# Altium schematic sheet Comparator.SchDoc
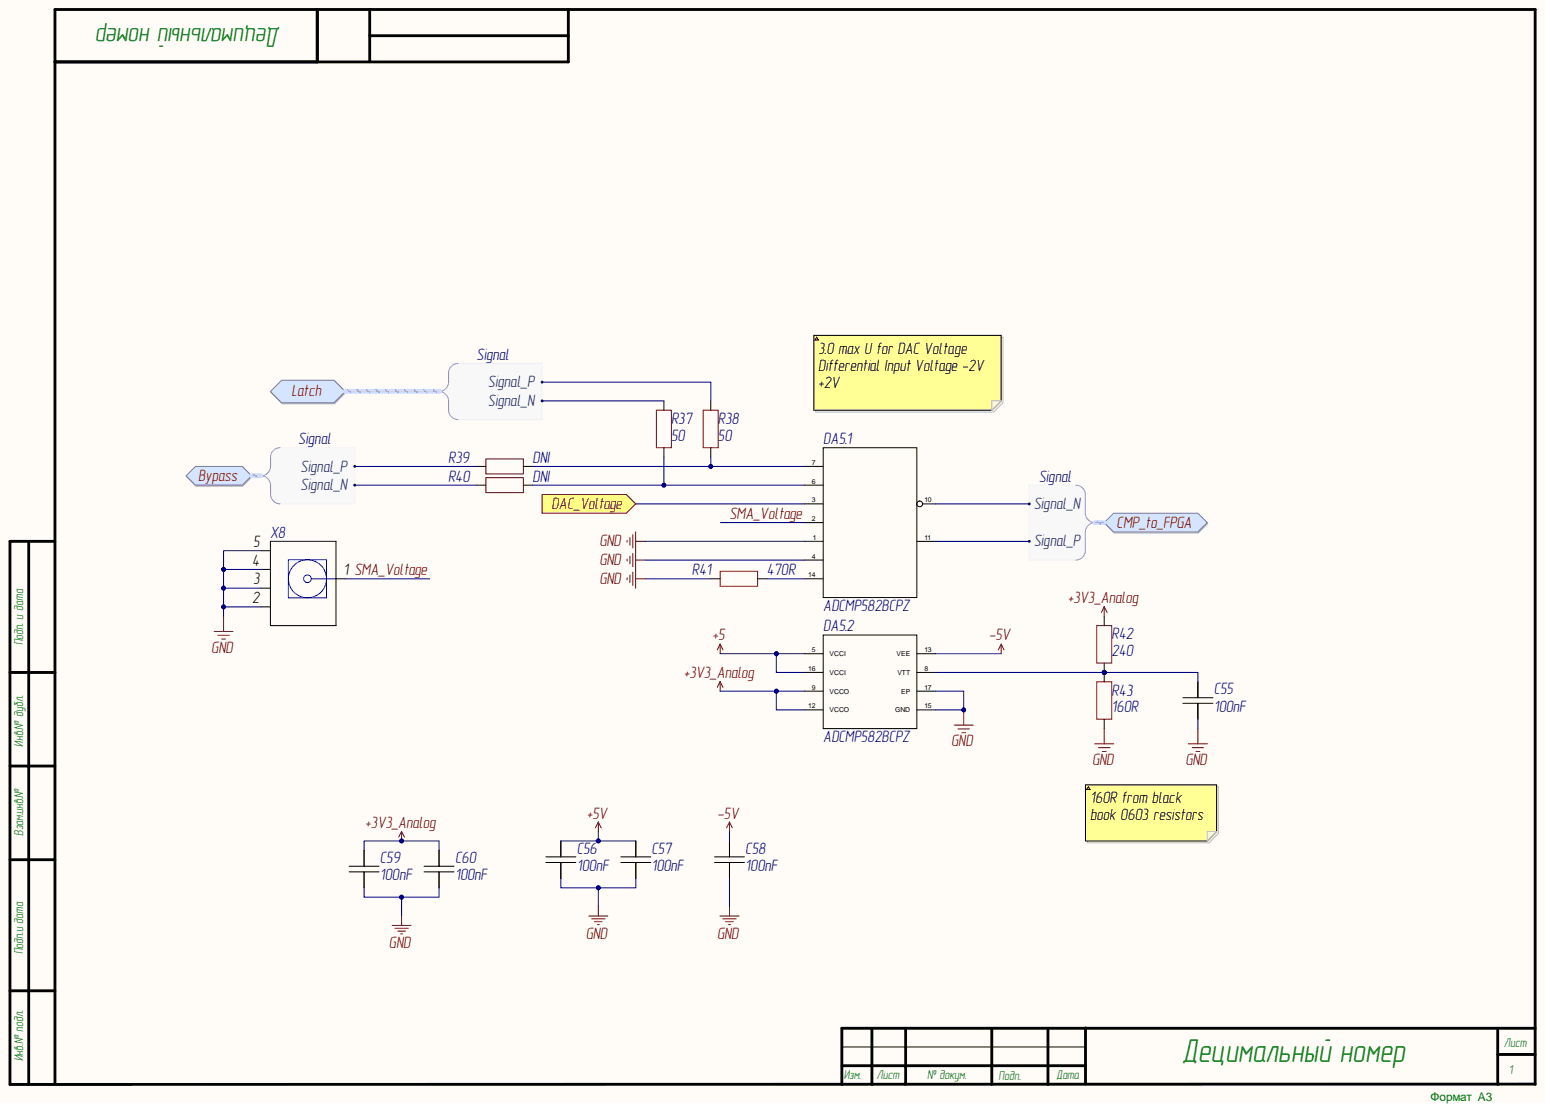
[diagram p0: Comparator.SchDoc]

## Parts
C55  value 100nF  [CAP0402]  (hidden comment: 100nF 50V)
C56  value 100nF  [CAP0402]  (hidden comment: 100nF 50V)
C57  value 100nF  [CAP0402]  (hidden comment: 100nF 50V)
C58  value 100nF  [CAP0402]  (hidden comment: 100nF 50V)
C59  value 100nF  [CAP0402]  (hidden comment: 100nF 50V)
C60  value 100nF  [CAP0402]  (hidden comment: 100nF 50V)
DA5  =Value  [ADI-CP-16-3_N]  (parts .1,.2)
R37  50  [RESC0402(1005)_M]
R38  50  [RESC0402(1005)_M]
R39  DNI  [RESC0402(1005)_M]
R40  DNI  [RESC0402(1005)_M]
R41  470R  [RESC0805L]
R42  240  [RESC0603N]
R43  160R  [RESC0603N]
X8  =Value  [60311042114502]

## Ports
Bypass, Bypass.Signal_N, Bypass.Signal_P, CMP_to_FPGA, CMP_to_FPGA.Signal_N, CMP_to_FPGA.Signal_P, DAC_Voltage, Latch, Latch.Signal_N, Latch.Signal_P

## Nets
+3V3_Analog: C59.1 C60.1 DA5.9(VCCO) DA5.12(VCCO) R42.2
+5: DA5.5(VCCI) DA5.16(VCCI)
+5V: C56.1 C57.1
-5V: C58.1 DA5.13(VEE)
GND: C55.1 C56.2 C57.2 C58.2 C59.2 C60.2 DA5.1 DA5.4 DA5.15(GND) DA5.17(EP) R41.1 R43.1 X8.2 X8.3 X8.4 X8.5
DAC_Voltage: DA5.3 ; port DAC_Voltage
SMA_Voltage: DA5.2 X8.1
NetC55_2: C55.2 DA5.8(VTT) R42.1 R43.2
NetDA5_6: DA5.6 R37.1 R40.1
NetDA5_7: DA5.7 R38.1 R39.1
NetDA5_10: DA5.10 ; port CMP_to_FPGA
NetDA5_11: DA5.11 ; port CMP_to_FPGA
NetDA5_14: DA5.14 R41.2
NetR37_2: R37.2 ; port Latch
NetR38_2: R38.2 ; port Latch
NetR39_2: R39.2 ; port Bypass
NetR40_2: R40.2 ; port Bypass

## Library data of the parts
C55-C60 = CAP0402: Manufacturer: Samsung Electro-Mechanics; ManufacturerAlt: JLCPCB; MPN: CL05B104KB54PNC; MPNAlt: C307331; BOMDescription: SMD CHIP CERAMIC CAPACITOR CAP0402 100nF 10% 50V  CL05B104KB54PNC; Database Table Name: Symbol_SchLib; MntType: SMD; Notes: Basic Part; Tolerance: 10%; CFootprint: CAP0402; ClassName: Capacitor; Component Kind: Standard; ComponentLink1Description: Datasheet; ComponentLink1URL: https://datasheet.lcsc.com/lcsc/1810191222_Samsung-Electro-Mechanics-CL05B104KB54PNC_C307331.pdf; Height: 0.5mm; Library Name: Library.DbLib; MSL: MSL 1; Package: CAP0402; Part Number: CAP0402; PartType: CHIP CERAMIC CAPACITOR; Pins: 2; RoHS: Comply; Voltage: 50V; Weight: 1.500mg
DA5 = ADCMP582BCPZ: Ultrafast SiGe Voltage Comparator, + / - 5 V, 180 ps Delay, Industrial, 16-pin QFN (CP-16-3), Reel; Manufacturer: AD; BOMDescription: SMD Comparator LFCSP-16 =Value    ADCMP582BCPZ; Database Table Name: Symbol_SchLib; MntType: SMD; CFootprint: ADI-CP-16-3_N; ClassName: Comparator; Component Kind: Standard; ComponentLink1Description: Datasheet; ComponentLink1URL: https://www.analog.com/media/en/technical-documentation/data-sheets/ADCMP580_581_582.pdf; Library Name: Library.DbLib; MPN: ADCMP582BCPZ; MSL: MSL 1; Package: LFCSP-16; Part Number: ADCMP582BCPZ; PartType: Comparator; Pins: 16; RoHS: Comply
R37 R38 = RES0402: BOMDescription: SMD CHIP RESISTOR RESC0402(1005)_M 50; Database Table Name: Symbol_SchLib; MntType: SMD; CFootprint: RESC0402(1005)_M; ClassName: Resistor; Component Kind: Standard; ComponentLink1Description: Datasheet; Library Name: Library.DbLib; MSL: MSL 1; Package: RESC0402(1005)_M; Part Number: RES0402; PartType: CHIP RESISTOR; Pins: 2; RoHS: Comply; Value: 50
R39 R40 = RES0402: BOMDescription: SMD CHIP RESISTOR RESC0402(1005)_M DNI; Database Table Name: Symbol_SchLib; MntType: SMD; CFootprint: RESC0402(1005)_M; ClassName: Resistor; Component Kind: Standard; ComponentLink1Description: Datasheet; Library Name: Library.DbLib; MSL: MSL 1; Package: RESC0402(1005)_M; Part Number: RES0402; PartType: CHIP RESISTOR; Pins: 2; RoHS: Comply; Value: DNI
R41 = RES: ManufacturerAlt: 0805W8F4700T5E; MPN: JLCPCB; MPNAlt: C17710; BOMDescription: SMD CHIP RESISTOR RESC0805L 470R    JLCPCB; Database Table Name: Symbol_SchLib; MntType: SMD; CFootprint: RESC0805L; ClassName: Resistor; Component Kind: Standard; ComponentLink1Description: Datasheet; Library Name: Library.DbLib; MSL: MSL 1; Package: RESC0805L; Part Number: RES; PartType: CHIP RESISTOR; Pins: 2; RoHS: Comply; Value: 470R
R42 = RES: Manufacturer: UNI-ROYAL(Uniroyal Elec); ManufacturerAlt: JLCPCB; MPN: 0603WAF2400T5E; MPNAlt: C23350; BOMDescription: SMD CHIP RESISTOR RESC0603N 240    0603WAF2400T5E; Database Table Name: Symbol_SchLib; MntType: SMD; CFootprint: RESC0603N; ClassName: Resistor; Component Kind: Standard; ComponentLink1Description: Datasheet; Library Name: Library.DbLib; MSL: MSL 1; Package: RESC0603N; Part Number: RES; PartType: CHIP RESISTOR; Pins: 2; RoHS: Comply; Value: 240
R43 = RES: BOMDescription: SMD CHIP RESISTOR RESC0603N 160R; Database Table Name: Symbol_SchLib; MntType: SMD; CFootprint: RESC0603N; ClassName: Resistor; Component Kind: Standard; ComponentLink1Description: Datasheet; Library Name: Library.DbLib; MSL: MSL 1; Package: RESC0603N; Part Number: RES; PartType: CHIP RESISTOR; Pins: 2; RoHS: Comply; Value: 160R
X8 = SMA_WR_60311042114502: Manufacturer: WE; BOMDescription: SMD SMA  =Value    60311042114502; Database Table Name: Symbol_SchLib; MntType: SMD; CFootprint: 60311042114502; ClassName: SMA; Component Kind: Standard; ComponentLink1Description: Datasheet; ComponentLink1URL: https://katalog.we-online.de/em/datasheet/60311042114502.pdf; Library Name: Library.DbLib; MPN: 60311042114502; MSL: MSL 1; Part Number: SMA_WR_60311042114502; PartType: SMA; Pins: 5; RoHS: Comply
